# Altium schematic sheet S7CAS-PLEIADES-CARTE-MERE_P01_IO.SchDoc
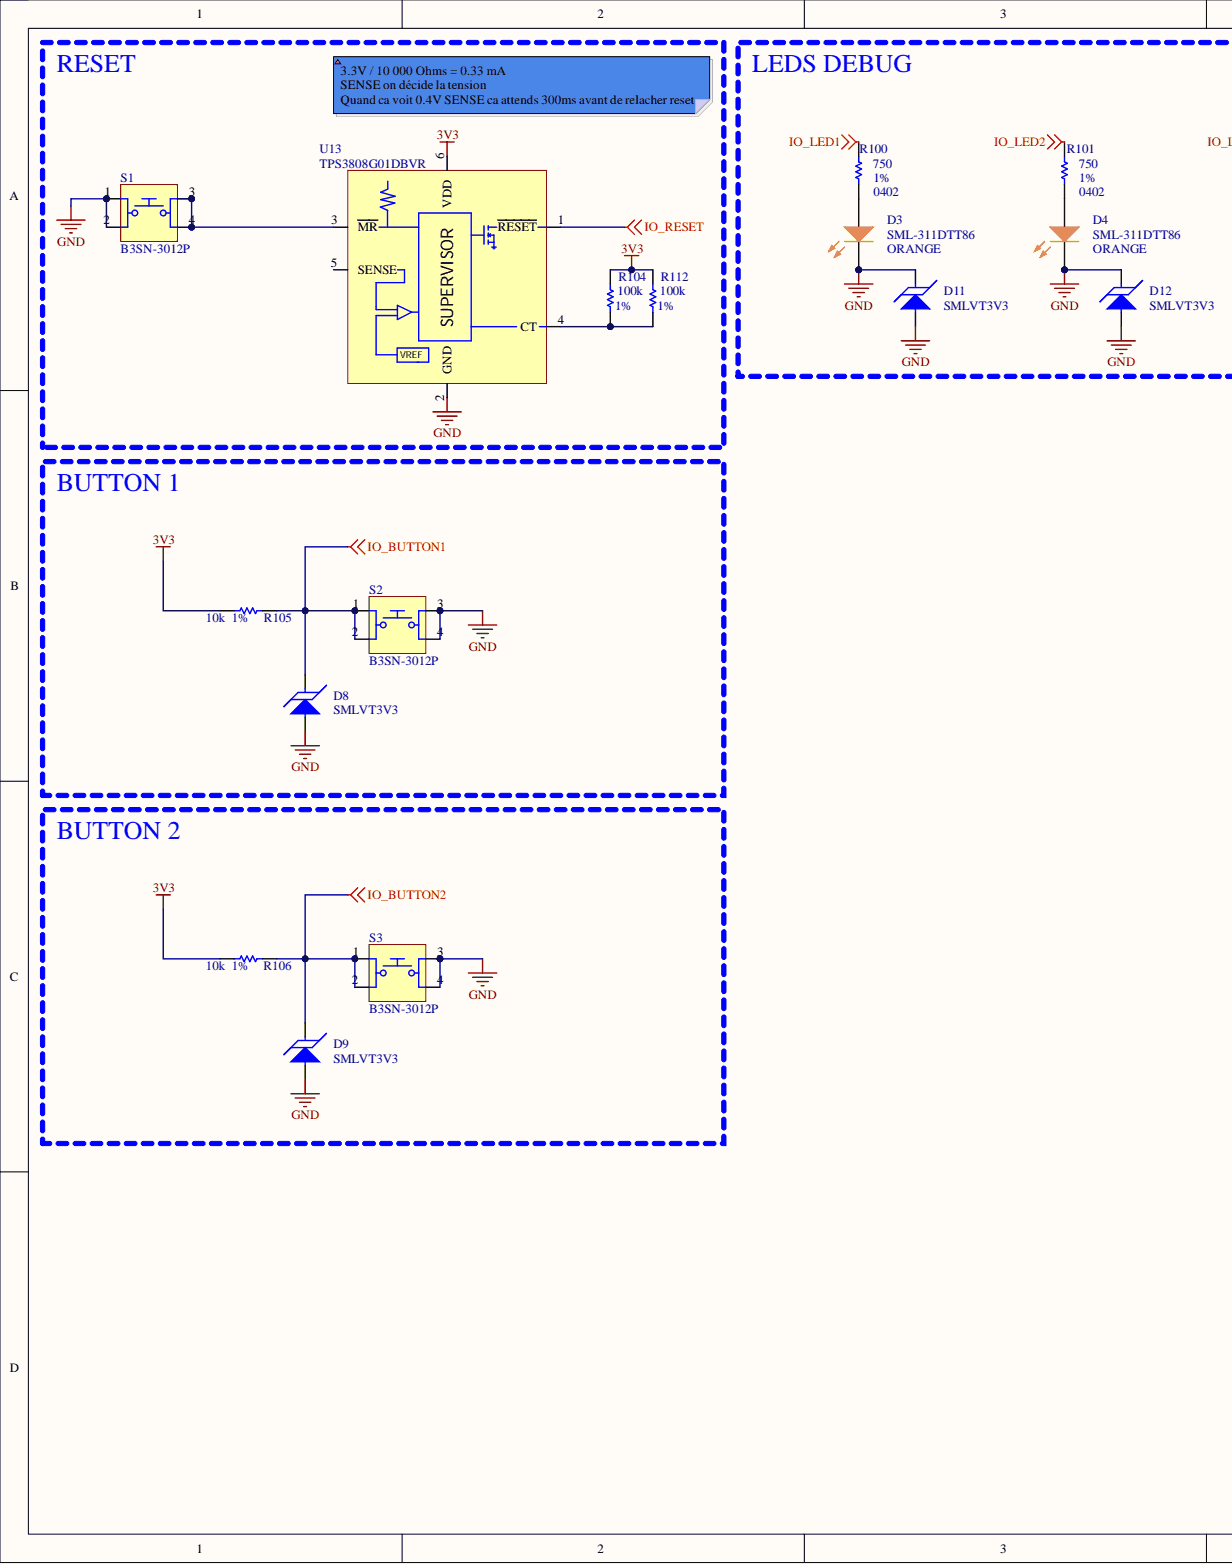
[diagram p0: S7CAS-PLEIADES-CARTE-MERE_P01_IO.SchDoc, left]
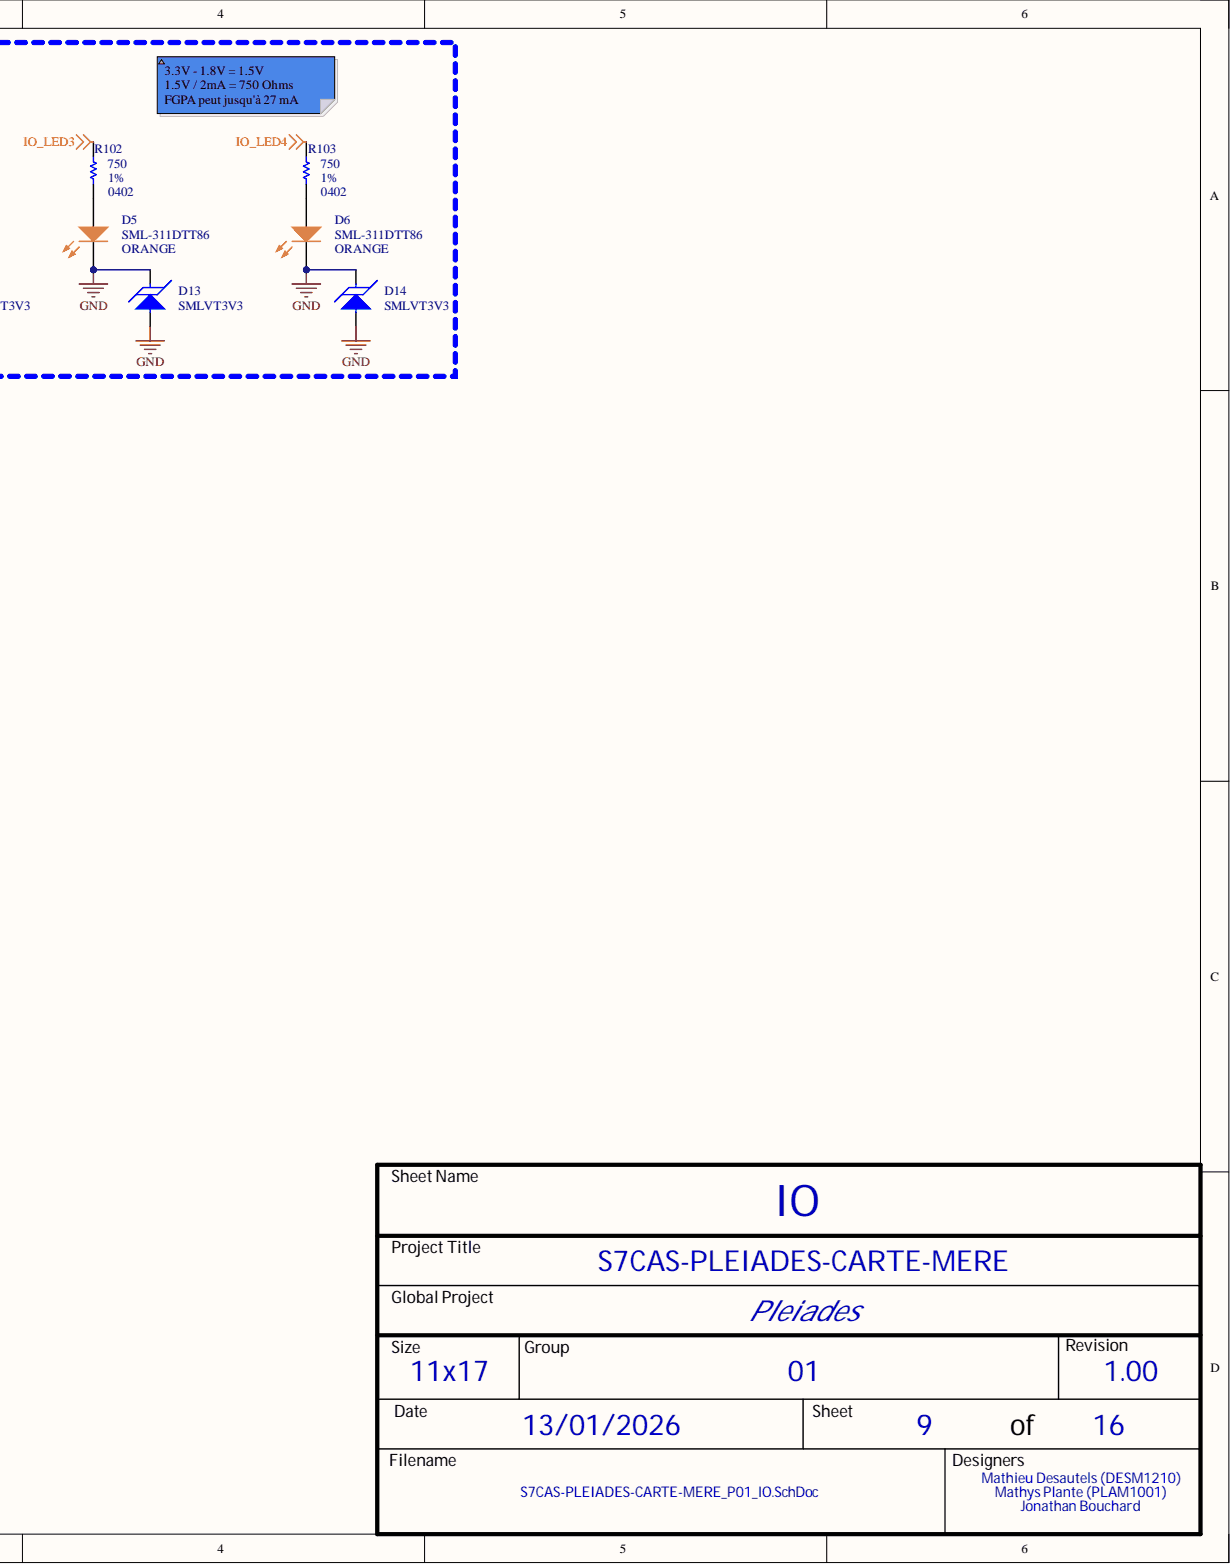
[diagram p1: S7CAS-PLEIADES-CARTE-MERE_P01_IO.SchDoc, right]

## Parts
D3  SML-311DTT86  value ORANGE  [LED_0603]
D4  SML-311DTT86  value ORANGE  [LED_0603]
D5  SML-311DTT86  value ORANGE  [LED_0603]
D6  SML-311DTT86  value ORANGE  [LED_0603]
D8  SMLVT3V3  [TVS_POW_SMLVT3V3]
D9  SMLVT3V3  [TVS_POW_SMLVT3V3]
D11  SMLVT3V3  [TVS_POW_SMLVT3V3]
D12  SMLVT3V3  [TVS_POW_SMLVT3V3]
D13  SMLVT3V3  [TVS_POW_SMLVT3V3]
D14  SMLVT3V3  [TVS_POW_SMLVT3V3]
R100  value 750  Precision: 1%  [RES_0402]  (hidden comment: RES - 0402)
R101  value 750  Precision: 1%  [RES_0402]  (hidden comment: RES - 0402)
R102  value 750  Precision: 1%  [RES_0402]  (hidden comment: RES - 0402)
R103  value 750  Precision: 1%  [RES_0402]  (hidden comment: RES - 0402)
R104  value 100k  Precision: 1%  [RES_0402]  (hidden comment: RES - 0402)
R105  value 10k  Precision: 1%  [RES_0402]  (hidden comment: RES - 0402)
R106  value 10k  Precision: 1%  [RES_0402]  (hidden comment: RES - 0402)
R112  value 100k  Precision: 1%  [RES_0402]  (hidden comment: RES - 0402)
S1  B3SN-3012P  [SWI_TACT_B3SN-3012P]
S2  B3SN-3012P  [SWI_TACT_B3SN-3012P]
S3  B3SN-3012P  [SWI_TACT_B3SN-3012P]
U13  TPS3808G01DBVR  [POW_RESET_TPS3808]

## Nets
3V3: R104.2 R105.1 R106.1 R112.2 U13.6(VDD)
GND: D3.K D4.K D5.K D6.K D8.A D9.A D11.A D11.K D12.A D12.K D13.A D13.K D14.A D14.K S1.1 S1.2 S2.3 S2.4 S3.3 S3.4 U13.2(GND)
IO_BUTTON1: D8.K R105.2 S2.1 S2.2
IO_BUTTON2: D9.K R106.2 S3.1 S3.2
IO_LED1: R100.2
IO_LED2: R101.2
IO_LED3: R102.2
IO_LED4: R103.2
IO_RESET: U13.1(RESET)
NetD3_A: D3.A R100.1
NetD4_A: D4.A R101.1
NetD5_A: D5.A R102.1
NetD6_A: D6.A R103.1
NetR104_1: R104.1 R112.1 U13.4(CT)
NetS1_3: S1.3 S1.4 U13.3(MR)

Not connected: U13 5(SENSE)

## Library data of the parts
D3-D6 = LED_SML-311DTT86: LED - 611nM ORANGE 2mA - 0603; Mfg: ROHM Semiconductor; Power: 44mW; Voltage: 1.8V; Component Kind: Standard; Current: 2mA; HelpURL: http://media.digikey.com/pdf/Data%20Sheets/Rohm%20PDFs/SML-311%20Series.pdf; Library Name: s7cas_lib2023.intlib; Mfg Part Number: SML-311DTT86; Package: 0603; Supplier 1: Digikey; Supplier Part Number 1: 511-1303-1-ND; Temperature: -40°C to 85°C; CreatedBy: Jonathan Bouchard
D8 D9 D11-D14 = TVS_POW_SMLVT3V3: TVS - 3.3V 10.3V 600W - DO214AA; Manufacturer #1: STMicroelectronics; Cryo: UNKNOWN; Current Rating: 200A (Ifsm); GRAMS Lib Spec: 2.8; Polarized: YES; Power Max: 600W (Ppp); Value: Vbr = 4.1V -  Clamp = 10.3V; Voltage Rating: 3.3V Standoff; Assembly Type: SMD; Component Kind: Standard; HelpURL: https://www.st.com/content/ccc/resource/technical/document/datasheet/da/a4/86/90/17/13/47/7c/CD00000923.pdf/files/CD00000923.pdf/jcr:content/translations/en.CD00000923.pdf; Library Name: s7cas_lib2023.intlib; Magnetic Material: UNKNOWN; Package: DO214AA; Part Number #1: SMLVT3V3; Supplier 1: Digi-Key; Supplier Part Number 1: 497-2496-1-ND; Temperature: -55°C ~ 175°C (TJ); CreatedBy/Date: Jonathan Bouchard - 2019-04-27; VerifiedBy: Nicolas V. (2019-05-06)
R100-R103 = RES - 0402: 750 Ohms ±0.1% 0.063W, 1/16W Chip Resistor 0402 (1005 Metric) Automotive AEC-Q200 Thin Film; Mfg Part Number: ERA-2ARB751X; Mfg: Panasonic Electronic Components; Voltage: 50V; Component Kind: Standard; Library Name: s7cas_lib2023.intlib; Package: 0402; Power: 1/16W; CreatedBy: Jonathan Bouchard
R104 R112 = RES - 0402: 100 kOhms ±1% 0.063W, 1/16W Chip Resistor 0402 (1005 Metric) Moisture Resistant Thick Film; Mfg Part Number: RC0402FR-07100KL; Voltage: 75V; Component Kind: Standard; Library Name: s7cas_lib2023.intlib; Package: 0402; Part Number #1: RC0402FR-07100KL; Power: 1/16W; CreatedBy: Jonathan Bouchard
R105 R106 = RES - 0402: 10 kOhms ±1% 0.063W, 1/16W Chip Resistor 0402 (1005 Metric) Automotive AEC-Q200 Thick Film; Mfg Part Number: RMCF0402FT10K0; Voltage: 75V; Component Kind: Standard; Library Name: s7cas_lib2023.intlib; Package: 0402; Part Number #1: RMCF0402FT10K0; Power: 1/16W; CreatedBy: Jonathan Bouchard
S1-S3 = SWI_TACT_B3SN-3012P_1: SWI - SWITCH TACTILE SPST-NO 0.05A 24V -SMD; Manufacturer #1: Omron Electronics Inc-EMC Div; Cryo: UNKNOWN; Current Rating: 50mA; GRAMS Lib Spec: 2.8; Polarized: No; Voltage rating: 24VDC; Assembly Type: SMD; Component Kind: Standard; HelpURL: http://omronfs.omron.com/en_US/ecb/products/pdf/en-b3sn.pdf; Library Name: s7cas_lib2023.intlib; Magnetic Material: UNKNOWN; Package: SMD; Part Number #1: B3SN-3012P; Supplier 1: Digi-Key; Supplier Part Number 1: SW261CT-ND; Temperature: -25°C ~ 70°C; Value: SPST-NO; CreatedBy/Date: Olivier Bernard / 5/12/2017; VerifiedBy: Caroline Paulin / 11 juillet 2018
U13 = POW_RESET_TPS3808G01DBVR: POW - VOLT SUPERVISOR ADJ - SOT23-6; Mfg: Texas Instruments; Component Kind: Standard; HelpURL: http://www.ti.com/lit/ds/symlink/tps3808.pdf; Library Name: s7cas_lib2023.intlib; Mfg Part Number: TPS3808G01DBVR; Package: SOT23-6; Supplier 1: Digikey; Supplier Part Number 1: 296-17188-6-ND; Temperature: -40°C ~ 125°C (TJ); CreatedBy: Jonathan Bouchard
